# Altium schematic sheet CarLogger.SchDoc(CarLogger)
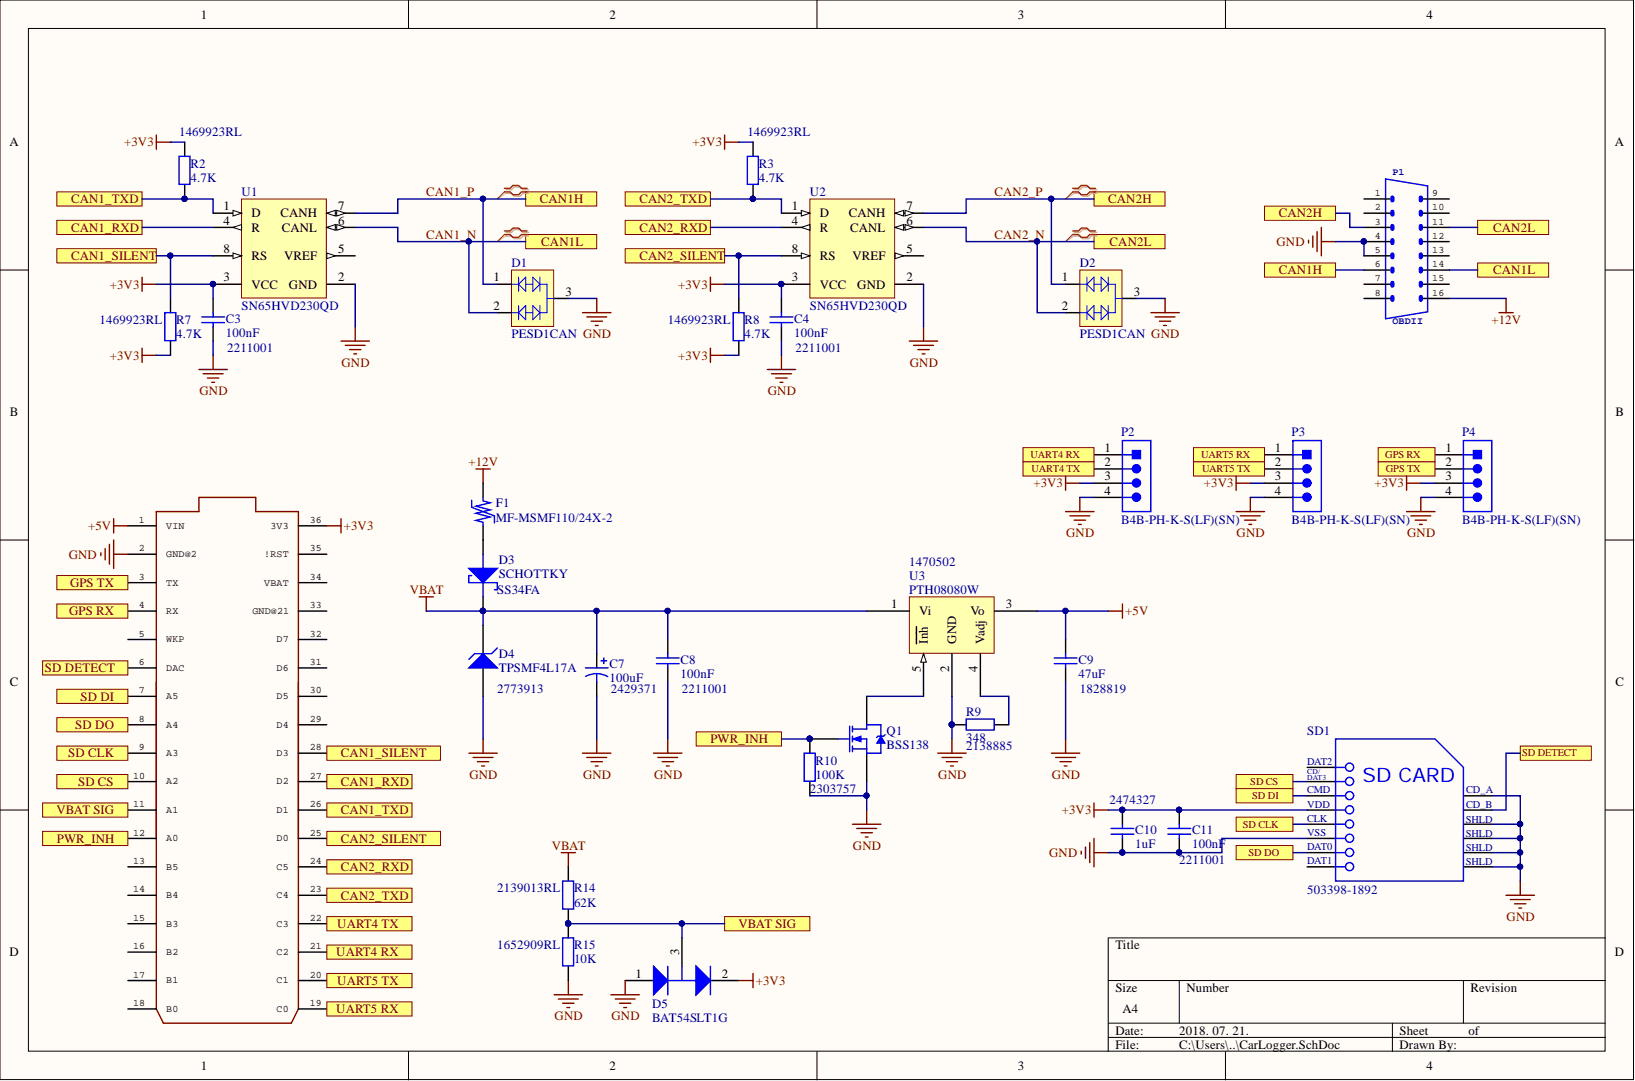
[diagram p0: CarLogger.SchDoc]

## Parts
C3  100nF  Supplier Part Number 1: 2211001  [FP_0805_CAP_085]
C4  100nF  Supplier Part Number 1: 2211001  [FP_0805_CAP_085]
C7  100uF  Supplier Part Number 1: 2429371  [FP_7343]
C8  100nF  Supplier Part Number 1: 2211001  [FP_0805_CAP_085]
C9  47uF  Supplier Part Number 1: 1828819  [FP_1210_CAP_250]
C10  1uF  Supplier Part Number 1: 2474327  [FP_0805_CAP_085]
C11  100nF  Supplier Part Number 1: 2211001  [FP_0805_CAP_085]
D1  PESD1CAN  [FP_SOT23-3]
D2  PESD1CAN  [FP_SOT23-3]
D3  SCHOTTKY  Manufacturer Part Number: SS34FA  [FP_SOD-123]
D4  TPSMF4L17A  Supplier Part Number 1: 2773913  [FP_SOD-123]
D5  BAT54SLT1G  [FP_SOT23-3]
F1  MF-MSMF110/24X-2  [MF-MSMF-2]
P1  OBDII  [OBDII-COMTECH]
P2  B4B-PH-K-S(LF)(SN)  [JST-B4B-PH-K-S_V]
P3  B4B-PH-K-S(LF)(SN)  [JST-B4B-PH-K-S_V]
P4  B4B-PH-K-S(LF)(SN)  [JST-B4B-PH-K-S_V]
Q1  BSS138  [FP_SOT23-3]
R2  4.7K  Supplier Part Number 1: 1469923RL  [FP_0805_RES]
R3  4.7K  Supplier Part Number 1: 1469923RL  [FP_0805_RES]
R7  4.7K  Supplier Part Number 1: 1469923RL  [FP_0805_RES]
R8  4.7K  Supplier Part Number 1: 1469923RL  [FP_0805_RES]
R9  348  Supplier Part Number 1: 2138885  [FP_0805_RES]
R10  100K  Supplier Part Number 1: 2303757  [FP_0805_RES]
R14  62K  Supplier Part Number 1: 2139013RL  [FP_0805_RES]
R15  10K  Supplier Part Number 1: 1652909RL  [FP_0805_RES]
SD1  503398-1892  [MOLX-SD-503398]
U1  SN65HVD230QD  [PCA82C250]
U2  SN65HVD230QD  [PCA82C250]
U3  PTH08080W  [PTH08080W]
U4  Particle Electron footprint  [ELECTRON]  (value not drawn)

## Ports
CAN1_RXD, CAN1_SILENT, CAN1_TXD, CAN1H, CAN1L, CAN2_RXD, CAN2_SILENT, CAN2_TXD, CAN2H, CAN2L, GPS RX, GPS TX, PWR_INH, SD CLK, SD CS, SD DETECT, SD DI, SD DO, UART4 RX, UART4 TX, UART5 RX, UART5 TX, VBAT SIG

## Nets
+3V3: C3.2 C4.2 C10.1 C11.2 D5.2 P2.3 P3.3 P4.3 R2.2 R3.2 R7.1 R8.1 SD1.4 U1.3(VCC) U2.3(VCC) U4.36(3V3)
+5V: C9.2 U3.3(Vo) U4.1(VIN)
+12V: F1.2 P1.16
GND: C3.1 C4.1 C7.N C8.1 C9.1 C10.2 C11.1 D1.3 D2.3 D4.2 D5.1 P1.4 P1.5 P2.4 P3.4 P4.4 Q1.2 R9.2 R10.1 R15.1 SD1.6 SD1.9 SD1.11 SD1.12 SD1.13 SD1.14 U1.2(GND) U2.2(GND) U3.2(GND) U4.2(GND@2)
VBAT: C7.P C8.2 D3.1 D4.1 R14.2 U3.1(Vi)
CAN1_N: D1.2 P1.14 U1.6(CANL) ; port CAN1L
CAN1_P: D1.1 P1.6 U1.7(CANH) ; port CAN1H
CAN1_RXD: U1.4(R) U4.27(D2) ; port CAN1_RXD
CAN1_SILENT: R7.2 U1.8(RS) U4.28(D3) ; port CAN1_SILENT
CAN1_TXD: R2.1 U1.1(D) U4.26(D1) ; port CAN1_TXD
CAN2_N: D2.2 P1.11 U2.6(CANL) ; port CAN2L
CAN2_P: D2.1 P1.3 U2.7(CANH) ; port CAN2H
CAN2_RXD: U2.4(R) U4.24(C5) ; port CAN2_RXD
CAN2_SILENT: R8.2 U2.8(RS) U4.25(D0) ; port CAN2_SILENT
CAN2_TXD: R3.1 U2.1(D) U4.23(C4) ; port CAN2_TXD
GPS RX: P4.1 U4.4(RX) ; port GPS RX
GPS TX: P4.2 U4.3(TX) ; port GPS TX
PWR_INH: Q1.1 R10.2 U4.12(A0) ; port PWR_INH
SD CLK: SD1.5 U4.9(A3) ; port SD CLK
SD CS: SD1.2(SD_CARD) U4.10(A2) ; port SD CS
SD DETECT: SD1.10 U4.6(DAC) ; port SD DETECT
SD DI: SD1.3 U4.7(A5) ; port SD DI
SD DO: SD1.7 U4.8(A4) ; port SD DO
UART4 RX: P2.1 U4.21(C2) ; port UART4 RX
UART4 TX: P2.2 U4.22(C3) ; port UART4 TX
UART5 RX: P3.1 U4.19(C0) ; port UART5 RX
UART5 TX: P3.2 U4.20(C1) ; port UART5 TX
VBAT SIG: D5.3 R14.1 R15.2 U4.11(A1) ; port VBAT SIG
NetD3_2: D3.2 F1.1
NetQ1_3: Q1.3 U3.5(Inh)
NetR9_1: R9.1 U3.4(Vadj)

Not connected: P1 1 2 7 8 9 10 12 13 15; SD1 1 8; U1 5(VREF); U2 5(VREF); U4 5(WKP) 13(B5) 14(B4) 15(B3) 16(B2) 17(B1) 18(B0) 29(D4) 30(D5) 31(D6) 32(D7) 33(GND@21) 34(VBAT) 35(!RST)

## Library data of the parts
C3 C4 C8 C11 = CAP: Capacitor; Manufacturer Part Number: CGA4J3X7T2E104K125AA; Manufacturer: TDK; Voltage Rating (DC): 250V; Tolerance: 10%; Dielectric: X7T; Width: 1.25mm; Weight: 0.000194oz; Voltage Rating: 250V; Value: 100nF; Thickness: 0.057inch; Termination: SMD/SMT; Supplier 1: Farnell; Stock 1: 0; Simulation: CAP; Signal Integrity: Cap; REACH SVHC: No SVHC; Radiation Hardening: No; Packaging: Tape and Reel; Package Quantity: 2000; Mount: Surface Mount; Min Operating Temperature: -55°C; Max Operating Temperature: 125°C; Library Name: CarLogger.SchLib; Length: 2mm; Height: 1.25mm; Depth: 1.25mm; Composition: Ceramic; ComponentLink8URL: https://datasheet.ciiva.com/8079/1807242-8079340.pdf; ComponentLink8Description: https://datasheet.ciiva.com/8079/1807242-8079340.pdf; ComponentLink7URL: https://datasheet.ciiva.com/22703/1807242-22703721.pdf; ComponentLink7Description: https://datasheet.ciiva.com/22703/1807242-22703721.pdf; ComponentLink6URL: https://datasheet.ciiva.com/14588/70095383-14588282.pdf; ComponentLink6Description: https://datasheet.ciiva.com/14588/70095383-14588282.pdf; ComponentLink5URL: https://datasheet.ciiva.com/7842/kem-c1002-x7r-smd-7842538.pdf; ComponentLink5Description: https://datasheet.ciiva.com/7842/kem-c1002-x7r-smd-7842538.pdf; ComponentLink4URL: https://datasheet.ciiva.com/7842/1851736-7842537.pdf; ComponentLink4Description: https://datasheet.ciiva.com/7842/1851736-7842537.pdf; ComponentLink3URL: https://datasheet.ciiva.com/14598/70095383-14598280.pdf; ComponentLink3Description: https://datasheet.ciiva.com/14598/70095383-14598280.pdf; ComponentLink2URL: https://datasheet.ciiva.com/7842/kem-c1002-x7r-smd-7842536.pdf; ComponentLink2Description: https://datasheet.ciiva.com/7842/kem-c1002-x7r-smd-7842536.pdf; ComponentLink1URL: https://datasheet.ciiva.com/7842/1598273-7842182.pdf; ComponentLink1Description: https://datasheet.ciiva.com/7842/1598273-7842182.pdf; Component Kind: Standard; Case Code (Metric): 2012; Case Code (Imperial): 0805; Case/Package: 0805; Capacitance: 100nF
C7 = CAP_ELECT: Polarized Capacitor; Manufacturer Part Number: T495X107K025ATE150; Manufacturer: KEMET; Voltage Rating (DC): 25V; Polarity: Polar; Life (Hours): 2000Hour; ESR (Equivalent Series Resistance): 150mR; Dissipation Factor: 10%; Width: 0.169inch; Voltage Rating: 25V; Value: 100uF; Supplier 1: Farnell; Stock 1: 2362; REACH SVHC: No SVHC; Radiation Hardening: No; Pricing 1: 1=4.5, 10=3.31, 50=2.5, 100=2.04, 200=1.81, 500=1.73, 2500=1.69 (EUR); Packaging: Cut Tape; Package Quantity: 500; Mount: Surface Mount; Min Operating Temperature: -55°C; Max Operating Temperature: 125°C; Library Name: CarLogger.SchLib; Length: 7.3mm; Lead Free: Lead Free; Height - Seated (Max): 0.169inch; Height: 4mm; Depth: 4.3mm; ComponentLink6URL: http://www.kemet.com/docfinder?Partnumber=T495X107K025ATE150; ComponentLink6Description: http://www.kemet.com/docfinder?Partnumber=T495X107K025ATE150; ComponentLink5URL: http://www.farnell.com/datasheets/1881875.pdf; ComponentLink5Description: http://www.farnell.com/datasheets/1881875.pdf; ComponentLink4URL: http://capacitoredge.kemet.com/capedge2/DataSheet?pn=T495X107K025ATE150; ComponentLink4Description: http://capacitoredge.kemet.com/capedge2/DataSheet?pn=T495X107K025ATE150; ComponentLink3URL: http://www.farnell.com/datasheets/2122368.pdf; ComponentLink3Description: http://www.farnell.com/datasheets/2122368.pdf; ComponentLink2URL: http://components.arrow.com/part/dataview/0323_07177846; ComponentLink2Description: http://components.arrow.com/part/dataview/0323_07177846; ComponentLink1URL: http://www.farnell.com/datasheets/2373574.pdf; ComponentLink1Description: http://www.farnell.com/datasheets/2373574.pdf; Component Kind: Standard; Case Code (Metric): 7343; Case Code (Imperial): 2917; Case/Package: Molded; Capacitance: 100uF
C9 = CAP: Capacitor; Manufacturer Part Number: GRM32ER61C476KE15L; Manufacturer: Murata; Voltage Rating (DC): 16V; Material: Ceramic; Dielectric: X5R; Width: 0.098inch; Voltage Rating: 16V; Value: 47uF; Thickness: 0.106inch; Termination: SMD/SMT; Supplier 1: Farnell; Stock 1: 17102; Simulation: CAP; Signal Integrity: Cap; REACH SVHC: No SVHC; Radiation Hardening: No; Pricing 1: 1=0.961, 50=0.652, 100=0.467, 250=0.437, 500=0.361 (GBP); Packaging: Cut Tape; Package Quantity: 1; Number of Pins: 2; Mount: Surface Mount; Min Operating Temperature: -55°C; Max Operating Temperature: 85°C; Library Name: CarLogger.SchLib; Length: 3.2mm; Lead Free: Lead Free; Height: 2.5mm; Depth: 2.5mm; ComponentLink8URL: https://datasheet.ciiva.com/6264/partnumbering-e-01-6264495.pdf; ComponentLink8Description: https://datasheet.ciiva.com/6264/partnumbering-e-01-6264495.pdf; ComponentLink7URL: https://datasheet.ciiva.com/16625/product-16625285.pdf; ComponentLink7Description: https://datasheet.ciiva.com/16625/product-16625285.pdf; ComponentLink6URL: https://datasheet.ciiva.com/16497/product-16497029.pdf; ComponentLink6Description: https://datasheet.ciiva.com/16497/product-16497029.pdf; ComponentLink5URL: https://datasheet.ciiva.com/29201/451871-da-01-de-vs-kondens-grm32-er61c-476ke15l-29201820.pdf; ComponentLink5Description: https://datasheet.ciiva.com/29201/451871-da-01-de-vs-kondens-grm32-er61c-476ke15l-29201820.pdf; ComponentLink4URL: https://datasheet.ciiva.com/29202/451184-da-01-de-vs-chip-kond-1210-47-0-uf-10pr-16-0v-x5r-29202483.pdf; ComponentLink4Description: https://datasheet.ciiva.com/29202/451184-da-01-de-vs-chip-kond-1210-47-0-uf-10pr-16-0v-x5r-29202483.pdf; ComponentLink3URL: https://datasheet.ciiva.com/6173/1747491-6173112.pdf; ComponentLink3Description: https://datasheet.ciiva.com/6173/1747491-6173112.pdf; ComponentLink2URL: https://datasheet.ciiva.com/6264/c02e-6264496.pdf; ComponentLink2Description: https://datasheet.ciiva.com/6264/c02e-6264496.pdf; ComponentLink1URL: https://datasheet.ciiva.com/5411/product-5411296.pdf; ComponentLink1Description: https://datasheet.ciiva.com/5411/product-5411296.pdf; Component Kind: Standard; Case Code (Metric): 3225; Case Code (Imperial): 1210; Case/Package: 1210; Capacitance: 47uF
C10 = CAP: Capacitor; Manufacturer Part Number: C0805C105J4RACTU; Manufacturer: KEMET; Voltage Rating (DC): 16V; Dielectric Material: Ceramic; Dielectric: X7R; Width: 0.049inch; Voltage Rating: 16V; Value: 1uF; Thickness: 0.039inch; Termination: SMD/SMT; Supplier 1: Farnell; Stock 1: 0; Simulation: CAP; Signal Integrity: Cap; REACH SVHC: No SVHC; Packaging: Tape and Reel; Package Quantity: 1; Number of Pins: 2; Mount: Surface Mount; Min Operating Temperature: -55°C; Max Operating Temperature: 125°C; Library Name: CarLogger.SchLib; Length: 2mm; Lead Pitch: 0.75mm; Height: 0.9mm; Depth: 1.25mm; ComponentLink6URL: https://search.kemet.com/component-edge/download/datasheet/C0805C105J4RACTU.pdf; ComponentLink6Description: https://search.kemet.com/component-edge/download/datasheet/C0805C105J4RACTU.pdf; ComponentLink5URL: http://www.farnell.com/datasheets/1828800.pdf; ComponentLink5Description: http://www.farnell.com/datasheets/1828800.pdf; ComponentLink4URL: http://www.mouser.com/ds/2/212/KEM_C1002_X7R_SMD-1102033.pdf; ComponentLink4Description: http://www.mouser.com/ds/2/212/KEM_C1002_X7R_SMD-1102033.pdf; ComponentLink3URL: http://capacitoredge.kemet.com/capedge2/DataSheet?pn=C0805C105J4RACTU; ComponentLink3Description: http://capacitoredge.kemet.com/capedge2/DataSheet?pn=C0805C105J4RACTU; ComponentLink2URL: http://www.farnell.com/datasheets/2064986.pdf; ComponentLink2Description: http://www.farnell.com/datasheets/2064986.pdf; ComponentLink1URL: http://components.arrow.com/part/dataview/30748390S8976888N7429; ComponentLink1Description: http://components.arrow.com/part/dataview/30748390S8976888N7429; Component Kind: Standard; Case Code (Metric): 2012; Case Code (Imperial): 0805; Case/Package: 0805; Capacitance: 1uF
D1 D2 = NXP-PESD1CAN-SOT23-3: CAN Bus ESD Protection Diode, 200 W, 24 V, -65 to 150 degC, 3-Pin SOT23, RoHS, Tape and Reel; Manufacturer: NXP Semiconductors; TVS Polarity: Bidirectional; SVHC: NoSVHC(20-Jun-2013); Stand-off Voltage: 24V; SMD Marking: AN; Peak Pulse Power: 200W; Peak Pulse Current Ippm: 3A; No. of Pins: 3; Diode Type: BidirectionalTVS; Diode Case Style: SOT-23; Clamping Voltage Vc Max: 70V; Capacitance Cd @ Vr Typ: 11pF; Capacitance Cd @ Vr Max: 17pF; Breakover Voltage Min: 25.4V; Breakdown Voltage Range: 25.4Vto30.3V; Breakdown Voltage Max: 30.3V; Breakdown Voltage: 27.8V; Termination Type: SMD; Reverse Stand-Off Voltage Vrwm: 24V; Package / Case: SOT-23; Operating Temperature Range: -65degCto+150degC; Operating Temperature Min: -65degC; Operating Temperature Max: 150degC; Manufacturer URL: http://www.nxp.com/; Library Name: CarLogger.SchLib; Diode Configuration: Bidirectional; Datasheet Version: Rev. 04, 02/2008; Datasheet URL: https://datasheet.ciiva.com/22692/109497-22692467.pdf; Component Kind: Standard; Clamping Voltage @ 8/20µs Max: 70V; Breakdown Voltage Min: 25.4V
D3 = SCHOTTKY: Schottky diode; Manufacturer: ON Semiconductor / Fairchild; Max Reverse Voltage (DC): 40V; Max Forward Surge Current (Ifsm): 80A; Element Configuration: Single; Average Rectified Current: 3A; Weight: 0.019g; Supplier Part Number 1: 2822568; Supplier 1: Farnell; Stock 1: 465; Pricing 1: 5=0.355, 25=0.339, 100=0.212, 250=0.186, 500=0.159, 1000=0.119, 5000=0.117 (EUR); Packaging: Tape and Reel; Mount: Surface Mount; Max Repetitive Reverse Voltage (Vrrm): 40V; ComponentLink3URL: http://www.farnell.com/datasheets/2552647.pdf; ComponentLink3Description: http://www.farnell.com/datasheets/2552647.pdf; ComponentLink2URL: https://www.fairchildsemi.com/datasheets/SS/SS34FA.pdf; ComponentLink2Description: https://www.fairchildsemi.com/datasheets/SS/SS34FA.pdf; ComponentLink1URL: http://www.mouser.com/ds/2/149/SS34FA-1012641.pdf; ComponentLink1Description: http://www.mouser.com/ds/2/149/SS34FA-1012641.pdf; Component Kind: Standard; Case/Package: SOD; Library Name: hallglib.SchLib
D4 = ZENER: Zener Diode; Manufacturer: Littelfuse; Supplier 1: Farnell; Stock 1: 2995; Simulation: ZENER; Signal Integrity: D Zener; Pricing 1: 5=0.369, 25=0.326, 100=0.219, 250=0.197, 500=0.175, 1000=0.15, 5000=0.125 (EUR); Manufacturer Part Number: TPSMF4L17A; Library Name: CarLogger.SchLib; Component Kind: Standard
D5 = ONSC-D-A1K2C3-3: Dual Series Schottky Barrier Diodes, 3-Pin SOT-23, Pb-Free, Tape and Reel; Manufacturer: On Semiconductor; trr Max (ns): 5; IFSM Max (A): 0.6; Signal Integrity: Guessed Model; RoHS: TRUE; PartNumber: BAT54SLT1G; Packing: Tape and Reel; PackageVersion: Rev. AP, 11/2009; PackageReference: SOT-23-3-318-08; PackageDescription: 3-Pin Small Outline Transistor, Body 2.92 x 1.3 mm, Pitch 0.95 mm; Mounting Technology: Surface Mount; Library Name: CarLogger.SchLib; DatasheetVersion: Rev. 15; Configuration: Dual; ComponentLink3URL: http://www.onsemi.cn/pub_link/Collateral/318-08.PDF; ComponentLink3Description: Package Specification; ComponentLink2URL: http://www.onsemi.cn/pub_link/Collateral/BAT54SLT1-D.PDF; ComponentLink2Description: Datasheet; ComponentLink1URL: http://www.onsemi.com/; ComponentLink1Description: Manufacturer URL; Component Kind: Standard
F1 = PPTC-2: PTC Resettable Fuse, 24 V, 1.1 A, -40 to 85 degC, 2-Pin SMD, RoHS, Tape and Reel; Manufacturer: Bourns; Voltage Rating (DC): 24V; Power Dissipation: 800mW; Max Current Rating: 20A; Width: 3.41mm; Voltage Rating: 24V; Supply Voltage: 24V; RoHSCompliant: true; REACH SVHC: NoSVHC; Radiation Hardening: No; Packaging: TapeandReel; Package Reference: MF-MSMF110-24X-2; Package Description: 2-Pin Surface Mount Device, Body 4.6 x 3.24 mm; Number of Terminals: 2; Mount: SurfaceMount; Min Operating Temperature: -40degC; Max Operating Temperature: 85degC; Manufacturer URL: http://www.bourns.com/; Library Name: CarLogger.SchLib; Length: 4.83mm; Height: 1.6mm; Datasheet Version: Rev. AG, 08/2016; Datasheet URL: http://www.bourns.com/docs/Product-Datasheets/mfmsmf.pdf; Contact Plating: Gold; Component Kind: Standard; Case Code (Metric): 4532; Case Code (Imperial): 1812; Case/Package: 1812
P1 = M08X2-OBDII: .1" headers, two rows of 8 each; PARTNO: OBD-II; SymbolName_1: M2X8; GateName_1: G$1; DeviceSetName: M08X2; DeviceName: -OBDII-COMTECH; Library Name: CarLogger.SchLib; Component Kind: Standard; LibraryName: MuniRent
P2-P4 = CMP-2000-05165-1: Male Header, Pitch 2 mm, 1 x 4 Position, Height 6 mm, Tail Length 3.4 mm, -25 to 85 degC, RoHS, Bulk; Manufacturer: JST; Style/Orientation: StraightHeader/Plug; Pitch: 2mm; No of Positions: 4; Type: ShroudedHeader; Termination: Crimp; Manufacturer URL: http://www.jst.com/home2.html; Library Name: Altium Content Vault; Datasheet Version: Apr-09; Datasheet URL: http://datasheet.octopart.com/B4B-PH-K-S%28LF%29%28SN%29-JST-datasheet-10558886.pdf; Component Kind: Standard
Q1 = BSS138: NXP - BSP030,115 - MOSFET, N CH, 30V, 10A, SOT223; Manufacturer: ON Semiconductor / Fairchild; Voltage Rating (DC): 50V; Turn-On Delay Time: 2.5ns; Turn-Off Delay Time: 20ns; Threshold Voltage: 1.3V; Rise Time: 9ns; Rds On Max: 3.5R; Power Dissipation: 360mW; On-State Resistance: 6R; Number of Elements: 1; Input Capacitance: 27pF; Gate to Source Voltage (Vgs): 20V; Element Configuration: Single; Current Rating: 220mA; Width: 1.3mm; Weight: 0.03g; Termination: SMD/SMT; Supplier Part Number 1: 9845330; Supplier 1: Farnell; Stock 1: 0; REACH SVHC: No SVHC; Radiation Hardening: No; Packaging: Tape and Reel; Package Quantity: 1; Number of Pins: 3; Nominal Vgs: 1.3V; Mount: Surface Mount; Min Operating Temperature: -55°C; Max Power Dissipation: 360mW; Max Operating Temperature: 150°C; Manufacturer Part Number: BSS138; Library Name: CarLogger.SchLib; Length: 2.92mm; Lead Free: Lead Free; Height: 0.93mm; Fall Time: 9ns; Dual Supply Voltage: 50V; Drain to Source Voltage (Vdss): 50V; Drain to Source Resistance: 3.5R; Drain to Source Breakdown Voltage: 50V; Continuous Drain Current (ID): 220mA; Contact Plating: Tin,Matte; ComponentLink10URL: https://datasheet.ciiva.com/26229/bss138-26229007.pdf; ComponentLink10Description: https://datasheet.ciiva.com/26229/bss138-26229007.pdf; ComponentLink9URL: https://datasheet.ciiva.com/27050/784896-27050043.pdf; ComponentLink9Description: https://datasheet.ciiva.com/27050/784896-27050043.pdf; ComponentLink8URL: https://datasheet.ciiva.com/16834/bss138-16834843.pdf; ComponentLink8Description: https://datasheet.ciiva.com/16834/bss138-16834843.pdf; ComponentLink7URL: https://datasheet.ciiva.com/22655/193736-22655240.pdf; ComponentLink7Description: https://datasheet.ciiva.com/22655/193736-22655240.pdf; ComponentLink6URL: https://datasheet.ciiva.com/3304/bss138-3304424.pdf; ComponentLink6Description: https://datasheet.ciiva.com/3304/bss138-3304424.pdf; ComponentLink5URL: https://datasheet.ciiva.com/3304/bss138-3304423.pdf; ComponentLink5Description: https://datasheet.ciiva.com/3304/bss138-3304423.pdf; ComponentLink4URL: https://datasheet.ciiva.com/1254/193736-1254983.pdf; ComponentLink4Description: https://datasheet.ciiva.com/1254/193736-1254983.pdf; ComponentLink3URL: https://datasheet.ciiva.com/1190/193736-1190131.pdf; ComponentLink3Description: https://datasheet.ciiva.com/1190/193736-1190131.pdf; ComponentLink2URL: https://datasheet.ciiva.com/1190/193736-1190130.pdf; ComponentLink2Description: https://datasheet.ciiva.com/1190/193736-1190130.pdf; ComponentLink1URL: https://datasheet.ciiva.com/931/bss138-931776.pdf; ComponentLink1Description: https://datasheet.ciiva.com/931/bss138-931776.pdf; Component Kind: Standard; Case/Package: SOT-23
R2 R3 R7 R8 = RES: Resistor; Manufacturer Part Number: CRCW08054K70FKEA; Manufacturer: Vishay; Voltage Rating (DC): 150V; Temperature Coefficient: 100ppm/°C; Resistance: 4.7kR; Power Rating: 125mW; Width: 1.25mm; Weight: 0.000194oz; Voltage Rating: 150V; Value: 4.7K; Termination: SMD/SMT; Supplier 1: Farnell; Stock 1: 0; Simulation: RESISTOR; Signal Integrity: Res; REACH SVHC: Unknown; Radiation Hardening: No; Packaging: Tape and Reel; Package Quantity: 10; Number of Terminations: 2; Min Operating Temperature: -55°C; Military Standard: Not; Max Power Dissipation: 125mW; Max Operating Temperature: 155°C; Library Name: CarLogger.SchLib; Length: 2mm; Lead Free: Lead Free; Height: 0.45mm; Depth: 1.25mm; Contact Plating: Tin; Composition: Thick Film; ComponentLink9URL: https://datasheet.ciiva.com/2467/dcrcwe3-2467439.pdf; ComponentLink9Description: https://datasheet.ciiva.com/2467/dcrcwe3-2467439.pdf; ComponentLink8URL: https://datasheet.ciiva.com/2467/dcrcwe3-2467438.pdf; ComponentLink8Description: https://datasheet.ciiva.com/2467/dcrcwe3-2467438.pdf; ComponentLink7URL: https://datasheet.ciiva.com/2467/dcrcwe3-2467437.pdf; ComponentLink7Description: https://datasheet.ciiva.com/2467/dcrcwe3-2467437.pdf; ComponentLink6URL: https://datasheet.ciiva.com/9071/dcrcwe3-9071196.pdf; ComponentLink6Description: https://datasheet.ciiva.com/9071/dcrcwe3-9071196.pdf; ComponentLink5URL: https://datasheet.ciiva.com/26317/fld-crcw-e3-data-en-26317145.pdf; ComponentLink5Description: https://datasheet.ciiva.com/26317/fld-crcw-e3-data-en-26317145.pdf; ComponentLink4URL: https://datasheet.ciiva.com/5550/dcrcwe3-109170-5550903.pdf; ComponentLink4Description: https://datasheet.ciiva.com/5550/dcrcwe3-109170-5550903.pdf; ComponentLink3URL: https://datasheet.ciiva.com/16720/70201119-16720438.pdf; ComponentLink3Description: https://datasheet.ciiva.com/16720/70201119-16720438.pdf; ComponentLink2URL: https://datasheet.ciiva.com/16213/dcrcwe3-16213733.pdf; ComponentLink2Description: https://datasheet.ciiva.com/16213/dcrcwe3-16213733.pdf; ComponentLink1URL: https://datasheet.ciiva.com/11808/0900766b80d98b26-11808992.pdf; ComponentLink1Description: https://datasheet.ciiva.com/11808/0900766b80d98b26-11808992.pdf; Component Kind: Standard; Case Code (Metric): 2012; Case Code (Imperial): 0805; Case/Package: 0805
R9 = RES: Resistor; Manufacturer Part Number: CRCW0805348RFKEA; Manufacturer: Vishay; Voltage Rating (DC): 150V; Temperature Coefficient: 100ppm/°C; Resistance: 348R; Power Rating: 125mW; Width: 0.049inch; Weight: 0.000194oz; Voltage Rating: 150V; Value: 348; Termination: SMD/SMT; Supplier 1: Farnell; Stock 1: 2661; Simulation: RESISTOR; Signal Integrity: Res; REACH SVHC: Unknown; Radiation Hardening: No; Pricing 1: 10=0.0343, 100=0.024, 500=0.0185, 1000=0.0094, 2500=0.007, 5000=0.0056, 25000=0.0042 (EUR); Packaging: Tape and Reel; Package Quantity: 10; Number of Terminations: 2; Number of Pins: 2; Min Operating Temperature: -55°C; Military Standard: Not; Max Power Dissipation: 125mW; Max Operating Temperature: 155°C; Library Name: CarLogger.SchLib; Length: 2mm; Lead Free: Lead Free; Height: 0.02inch; Depth: 1.25mm; Contact Plating: Tin; Composition: Thick Film; ComponentLink7URL: https://datasheet.ciiva.com/5551/dcrcwe3-109170-5551167.pdf; ComponentLink7Description: https://datasheet.ciiva.com/5551/dcrcwe3-109170-5551167.pdf; ComponentLink6URL: https://datasheet.ciiva.com/2467/dcrcwe3-2467183.pdf; ComponentLink6Description: https://datasheet.ciiva.com/2467/dcrcwe3-2467183.pdf; ComponentLink5URL: https://datasheet.ciiva.com/7725/125364-7725934.pdf; ComponentLink5Description: https://datasheet.ciiva.com/7725/125364-7725934.pdf; ComponentLink4URL: https://datasheet.ciiva.com/2467/dcrcwe3-2467182.pdf; ComponentLink4Description: https://datasheet.ciiva.com/2467/dcrcwe3-2467182.pdf; ComponentLink3URL: https://datasheet.ciiva.com/16776/70201119-16776235.pdf; ComponentLink3Description: https://datasheet.ciiva.com/16776/70201119-16776235.pdf; ComponentLink2URL: https://datasheet.ciiva.com/16396/dcrcwe3-16396282.pdf; ComponentLink2Description: https://datasheet.ciiva.com/16396/dcrcwe3-16396282.pdf; ComponentLink1URL: https://datasheet.ciiva.com/2467/dcrcwe3-2467184.pdf; ComponentLink1Description: https://datasheet.ciiva.com/2467/dcrcwe3-2467184.pdf; Component Kind: Standard; Case Code (Metric): 2012; Case Code (Imperial): 0805; Case/Package: 0805
R10 = RES: Resistor; Manufacturer Part Number: ERJ-6ENF1003V; Manufacturer: Panasonic; Voltage Rating (DC): 150V; Temperature Coefficient: 100ppm/°C; Resistance: 100kR; Power Rating: 125mW; Width: 0.049inch; Voltage Rating: 150V; Value: 100K; Termination: SMD/SMT; Supplier 1: Farnell; Stock 1: 44732; Simulation: RESISTOR; Signal Integrity: Res; REACH SVHC: No SVHC; Radiation Hardening: No; Pricing 1: 10=0.0222, 100=0.0162, 500=0.0137, 1000=0.0099 (EUR); Packaging: Tape and Reel; Package Quantity: 1; Number of Terminations: 2; Number of Pins: 2; Mount: Surface Mount; Min Operating Temperature: -55°C; Military Standard: Not; Max Power Dissipation: 125mW; Max Operating Temperature: 155°C; Library Name: CarLogger.SchLib; Length: 2mm; Lead Free: Lead Free; Height: 0.6mm; Depth: 1.25mm; Contact Plating: Tin; Composition: Thick Film; ComponentLink14URL: https://datasheet.ciiva.com/48531/aoa0000ce2-48531486.pdf; ComponentLink14Description: https://datasheet.ciiva.com/48531/aoa0000ce2-48531486.pdf; ComponentLink13URL: https://datasheet.ciiva.com/16788/70260748-16788734.pdf; ComponentLink13Description: https://datasheet.ciiva.com/16788/70260748-16788734.pdf; ComponentLink12URL: https://datasheet.ciiva.com/16776/70260748-16776892.pdf; ComponentLink12Description: https://datasheet.ciiva.com/16776/70260748-16776892.pdf; ComponentLink11URL: https://datasheet.ciiva.com/7830/426256-7830988.pdf; ComponentLink11Description: https://datasheet.ciiva.com/7830/426256-7830988.pdf; ComponentLink10URL: https://datasheet.ciiva.com/30257/aoa0000ce2-30257690.pdf; ComponentLink10Description: https://datasheet.ciiva.com/30257/aoa0000ce2-30257690.pdf; ComponentLink9URL: https://datasheet.ciiva.com/7830/426256-7830746.pdf; ComponentLink9Description: https://datasheet.ciiva.com/7830/426256-7830746.pdf; ComponentLink8URL: https://datasheet.ciiva.com/24023/aoa0000ce2-24023497.pdf; ComponentLink8Description: https://datasheet.ciiva.com/24023/aoa0000ce2-24023497.pdf; ComponentLink7URL: https://datasheet.ciiva.com/22667/1678782-22667897.pdf; ComponentLink7Description: https://datasheet.ciiva.com/22667/1678782-22667897.pdf; ComponentLink6URL: https://datasheet.ciiva.com/17208/aoa0000ce2-17208153.pdf; ComponentLink6Description: https://datasheet.ciiva.com/17208/aoa0000ce2-17208153.pdf; ComponentLink5URL: https://datasheet.ciiva.com/16449/aoa0000ce2-16449480.pdf; ComponentLink5Description: https://datasheet.ciiva.com/16449/aoa0000ce2-16449480.pdf; ComponentLink4URL: https://datasheet.ciiva.com/27055/1988989-27055734.pdf; ComponentLink4Description: https://datasheet.ciiva.com/27055/1988989-27055734.pdf; ComponentLink3URL: https://datasheet.ciiva.com/46419/1678782-46419877.pdf; ComponentLink3Description: https://datasheet.ciiva.com/46419/1678782-46419877.pdf; ComponentLink2URL: https://datasheet.ciiva.com/22723/426256-22723909.pdf; ComponentLink2Description: https://datasheet.ciiva.com/22723/426256-22723909.pdf; ComponentLink1URL: https://datasheet.ciiva.com/11821/0900766b80f80784-11821507.pdf; ComponentLink1Description: https://datasheet.ciiva.com/11821/0900766b80f80784-11821507.pdf; Component Kind: Standard; Case Code (Metric): 2012; Case Code (Imperial): 0805; Case/Package: 0805
R14 = RES: Resistor; Manufacturer Part Number: CRCW080562K0FKEA; Manufacturer: Vishay; Voltage Rating (DC): 150V; Temperature Coefficient: 100ppm/°C; Resistance: 62kR; Power Rating: 125mW; Width: 1.25mm; Weight: 0.000194oz; Voltage Rating: 150V; Value: 62K; Termination: SMD/SMT; Supplier 1: Farnell; Stock 1: 0; Simulation: RESISTOR; Signal Integrity: Res; REACH SVHC: Unknown; Radiation Hardening: No; Packaging: Tape and Reel; Package Quantity: 5000; Number of Pins: 2; Mount: Surface Mount; Min Operating Temperature: -55°C; Military Standard: Not; Max Operating Temperature: 155°C; Library Name: CarLogger.SchLib; Length: 2mm; Lead Free: Lead Free; Height: 0.45mm; Depth: 1.25mm; Contact Plating: Tin; Composition: Thick Film; ComponentLink7URL: https://datasheet.ciiva.com/7816/1697337-7816110.pdf; ComponentLink7Description: https://datasheet.ciiva.com/7816/1697337-7816110.pdf; ComponentLink6URL: https://datasheet.ciiva.com/7816/1697337-7816109.pdf; ComponentLink6Description: https://datasheet.ciiva.com/7816/1697337-7816109.pdf; ComponentLink5URL: https://datasheet.ciiva.com/5552/dcrcwe3-109170-5552189.pdf; ComponentLink5Description: https://datasheet.ciiva.com/5552/dcrcwe3-109170-5552189.pdf; ComponentLink4URL: https://datasheet.ciiva.com/26314/fld-crcw-e3-data-en-26314587.pdf; ComponentLink4Description: https://datasheet.ciiva.com/26314/fld-crcw-e3-data-en-26314587.pdf; ComponentLink3URL: https://datasheet.ciiva.com/22705/1697337-22705883.pdf; ComponentLink3Description: https://datasheet.ciiva.com/22705/1697337-22705883.pdf; ComponentLink2URL: https://datasheet.ciiva.com/16394/dcrcwe3-16394519.pdf; ComponentLink2Description: https://datasheet.ciiva.com/16394/dcrcwe3-16394519.pdf; ComponentLink1URL: https://datasheet.ciiva.com/11809/0900766b80d98b26-11809850.pdf; ComponentLink1Description: https://datasheet.ciiva.com/11809/0900766b80d98b26-11809850.pdf; Component Kind: Standard; Case Code (Metric): 2012; Case Code (Imperial): 0805; Case/Package: 0805
R15 = RES: Resistor; Manufacturer Part Number: CRCW080510K0FKTA; Manufacturer: Vishay Dale; Voltage Rating (DC): 150V; Temperature Coefficient: 100ppm/°C; Resistance: 10kR; Power Rating: 125mW; Width: 0.049inch; Weight: 0.000194oz; Voltage Rating: 150V; Value: 10K; Supplier 1: Farnell; Stock 1: 0; Simulation: RESISTOR; Signal Integrity: Res; REACH SVHC: Unknown; Radiation Hardening: No; Power Dissipation: 125mW; Packaging: Tape and Reel; Package Quantity: 5000; Number of Terminations: 2; Number of Pins: 2; Mount: Surface Mount; Min Operating Temperature: -55°C; Military Standard: Not; Max Power Dissipation: 125mW; Max Operating Temperature: 155°C; Library Name: CarLogger.SchLib; Length: 2mm; Lead Free: Contains Lead; Height: 0.02inch; Depth: 1.25mm; Contact Plating: Tin,Lead; Composition: Thick Film; ComponentLink6URL: https://datasheet.ciiva.com/3258/dcrcw-3258027.pdf; ComponentLink6Description: https://datasheet.ciiva.com/3258/dcrcw-3258027.pdf; ComponentLink5URL: https://datasheet.ciiva.com/16498/dcrcw-16498298.pdf; ComponentLink5Description: https://datasheet.ciiva.com/16498/dcrcw-16498298.pdf; ComponentLink4URL: https://datasheet.ciiva.com/3258/dcrcw-3258026.pdf; ComponentLink4Description: https://datasheet.ciiva.com/3258/dcrcw-3258026.pdf; ComponentLink3URL: https://datasheet.ciiva.com/9052/dcrcw-9052662.pdf; ComponentLink3Description: https://datasheet.ciiva.com/9052/dcrcw-9052662.pdf; ComponentLink2URL: https://datasheet.ciiva.com/2567/dcrcw-2567012.pdf; ComponentLink2Description: https://datasheet.ciiva.com/2567/dcrcw-2567012.pdf; ComponentLink1URL: https://datasheet.ciiva.com/3883/dcrcw-3883030.pdf; ComponentLink1Description: https://datasheet.ciiva.com/3883/dcrcw-3883030.pdf; Component Kind: Standard; Case Code (Metric): 2012; Case Code (Imperial): 0805; Case/Package: 0805
SD1 = CMP-2000-05621-1: Micro SD Connector, Pitch 1.1 mm, 8 Position, Height 1.4 mm, -25 to 85 degC, RoHS, Tape and Reel; Manufacturer: Molex; Voltage Rating: 10VAC/DC; Current Rating: 500mA; Series: 503398; RoHS: Details; Product Category: MemoryCardConnectors; Packaging: Reel; Package Reference: 503398-1892; Package Description: Micro SD Connector, Pitch 1.1 mm, 8 Position, Height 1.4 mm; Mounting Technology: SurfaceMount; Manufacturer URL: http://www.molex.com/; Library Name: CarLogger.SchLib; Factory Pack Quantity: 2400; Datasheet Version: Rev. E, 04/2006; Datasheet URL: http://www.molex.com/pdm_docs/sd/5033981892_sd.pdf; Component Kind: Standard; Brand: Molex
U1 U2 = TI-SN65HVD230Q-SOIC_D-8: Automotive Catalog 3.3 V CAN Transceiver, 17 mA, -40 to 125 degC, 8-pin SOIC (D), Green (RoHS & no Sb/Br); Manufacturer: Texas Instruments; Transient Pulse Protection(V): -25 to 25; Supply Voltage(s)(V): 3.3; Receivers Per Package: 1; ICC(Max)(mA): 17; SubFamily: CAN; Rating: Catalog; PartNumber: SN65HVD230QD; PackageVersion: revM, Jun-2011; PackageReference: D0008A; PackageDescription: 8-Pin Plastic Small Outline Integrated Circuit, 4.9 x 3.9 mm, Pitch 1.27 mm Pitch; Mounting Technology: Surface Mount; Library Name: CarLogger.SchLib; Isolated: No; Eco-Plan: Green (RoHS & no Sb/Br); Drivers Per Package: 1; DatasheetVersion: SLOS346K; ComponentLink1URL: http://www.ti.com/lit/ds/symlink/sn65hvd230.pdf; ComponentLink1Description: Datasheet; Component Kind: Standard; Code_JEDEC: MS-012AA
U3 = TI-PTH08080W: PTH08080W 2.25-A, WIDE-INPUT ADJUSTABLE SWITCHING REGULATOR; Manufacturer Part Number: PTH08080WAH; Manufacturer: Texas Instruments; Voltage - Output 1: 5.5V; Output Power: 10W; Output Current: 2.25A; Number of Outputs: 1; Min Output Voltage: 900mV; Min Input Voltage (DC): 4.5V; Max Power Dissipation: 12.4W; Max Input Voltage (DC): 18V; Isolation: Non-Isolated; Efficiency: 93.5%; Width: 12.7mm; Supplier Part Number 1: 1470502; Supplier 1: Farnell; Stock 1: 297; REACH SVHC: No SVHC; Radiation Hardening: No; Pricing 1: 1=7.01, 5=6.29, 10=6.03, 50=5.83, 100=5.66, 250=5.02 (EUR); Packaging: Tray; Package Quantity: 90; Output Voltage: 5.5V; Number of Pins: 5; Min Operating Temperature: -40°C; Min Input Voltage: 4.5V; Max Output Voltage: 5.5V; Max Output Power: 10W; Max Output Current: 2.25A; Max Operating Temperature: 85°C; Max Input Voltage: 18V; Library Name: CarLogger.SchLib; Length: 15.24mm; Lead Free: Lead Free; Height: 0.32inch; Depth: 12.7mm; Current - Output 1: 2.25A; ComponentLink7URL: https://datasheet.ciiva.com/3240/pth08080w-3240460.pdf; ComponentLink7Description: https://datasheet.ciiva.com/3240/pth08080w-3240460.pdf; ComponentLink6URL: https://datasheet.ciiva.com/8042/1766996-8042603.pdf; ComponentLink6Description: https://datasheet.ciiva.com/8042/1766996-8042603.pdf; ComponentLink5URL: https://datasheet.ciiva.com/2169/pth08080w-2169547.pdf; ComponentLink5Description: https://datasheet.ciiva.com/2169/pth08080w-2169547.pdf; ComponentLink4URL: https://datasheet.ciiva.com/26253/pth08080w-26253173.pdf; ComponentLink4Description: https://datasheet.ciiva.com/26253/pth08080w-26253173.pdf; ComponentLink3URL: https://datasheet.ciiva.com/26919/pth08080wah-26919862.pdf; ComponentLink3Description: https://datasheet.ciiva.com/26919/pth08080wah-26919862.pdf; ComponentLink2URL: https://datasheet.ciiva.com/15112/getdatasheetpartid-239909-15112164.pdf; ComponentLink2Description: https://datasheet.ciiva.com/15112/getdatasheetpartid-239909-15112164.pdf; ComponentLink1URL: https://datasheet.ciiva.com/19457/1366910-19457299.pdf; ComponentLink1Description: https://datasheet.ciiva.com/19457/1366910-19457299.pdf; Component Kind: Standard; Case/Package: DIP
U4 = ELECTRON_WITH_HEADERS: Library Name: CarLogger.SchLib; Component Kind: Standard
